# Altium schematic sheet SIB Int Press & Mux.SchDoc
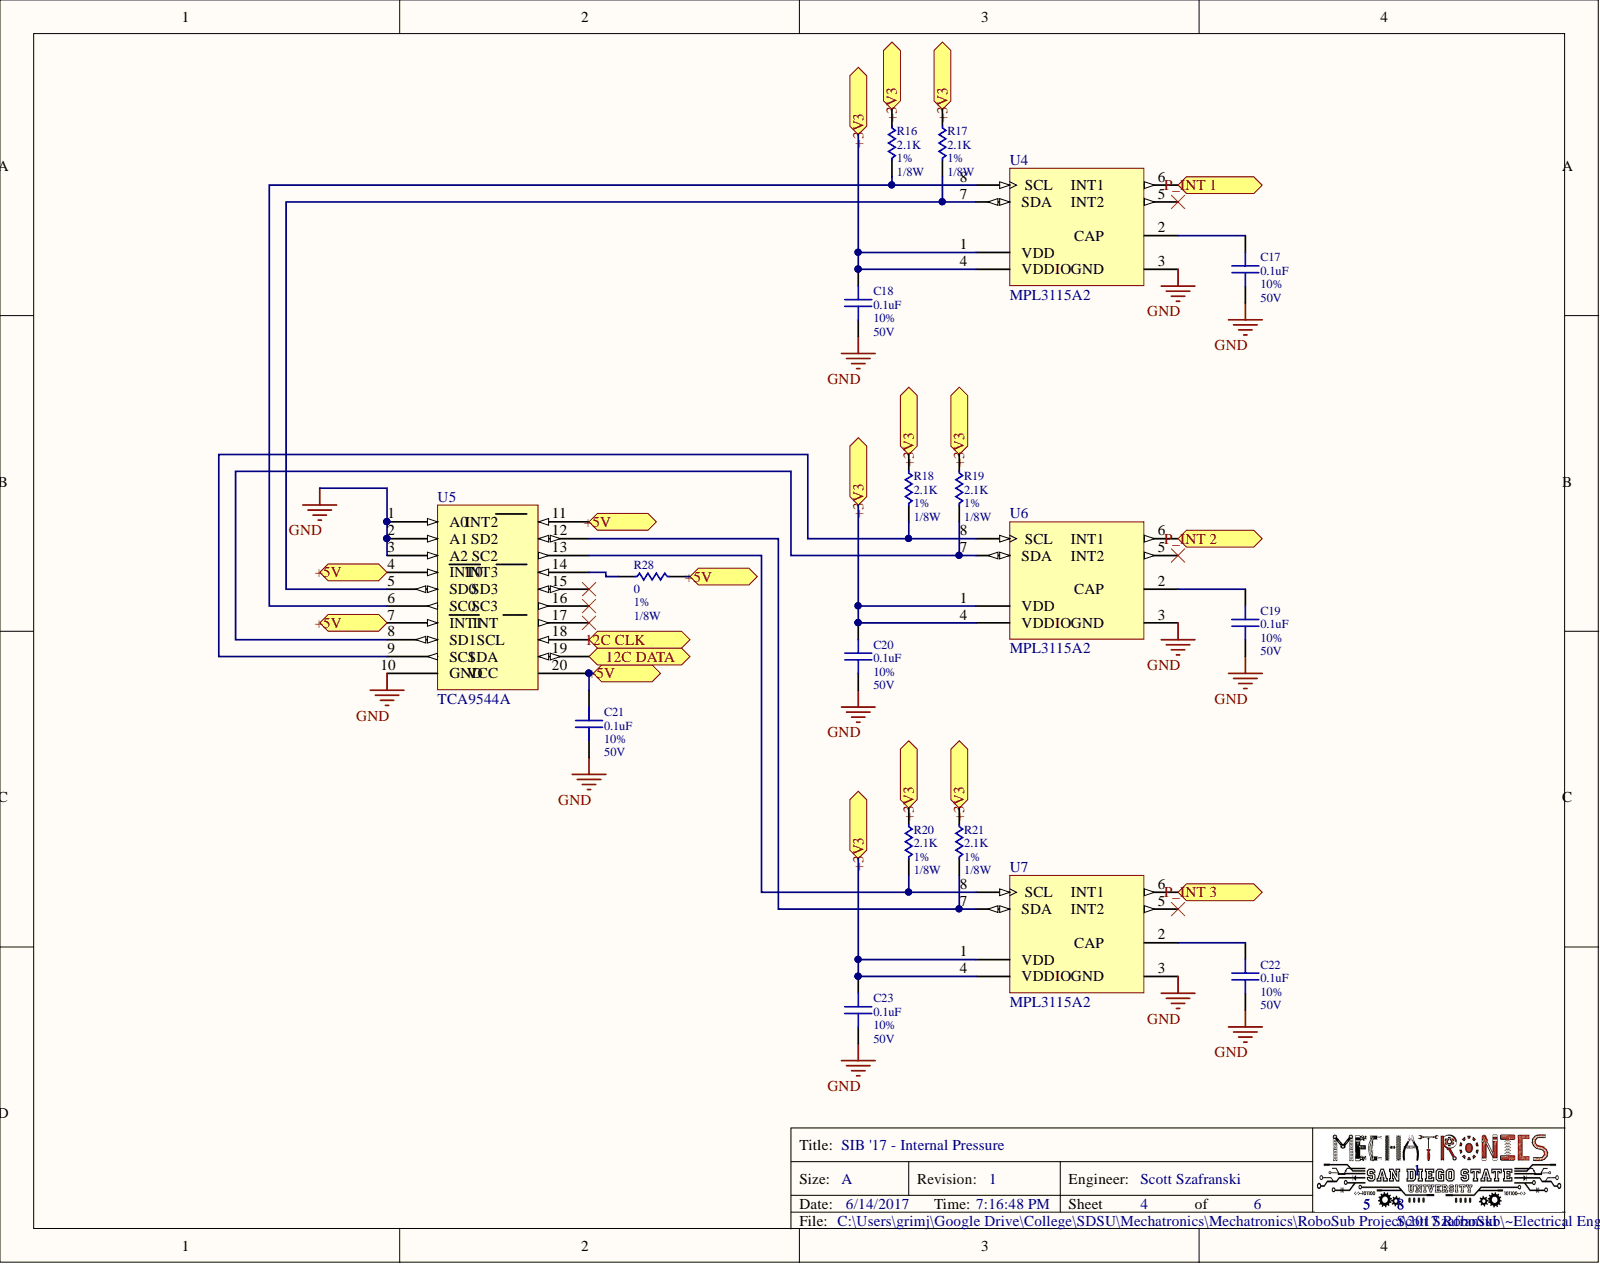
[diagram p0: SIB Int Press & Mux.SchDoc]

## Parts
C17  0.1uF  Tolerance: 10%  Voltage: 50V  [Component 0805]
C18  0.1uF  Tolerance: 10%  Voltage: 50V  [Component 0805]
C19  0.1uF  Tolerance: 10%  Voltage: 50V  [Component 0805]
C20  0.1uF  Tolerance: 10%  Voltage: 50V  [Component 0805]
C21  0.1uF  Tolerance: 10%  Voltage: 50V  [Component 0805]
C22  0.1uF  Tolerance: 10%  Voltage: 50V  [Component 0805]
C23  0.1uF  Tolerance: 10%  Voltage: 50V  [Component 0805]
R16  2.1K  Power: 1/8W  Tolerance: 1%  [Component 0805]
R17  2.1K  Power: 1/8W  Tolerance: 1%  [Component 0805]
R18  2.1K  Power: 1/8W  Tolerance: 1%  [Component 0805]
R19  2.1K  Power: 1/8W  Tolerance: 1%  [Component 0805]
R20  2.1K  Power: 1/8W  Tolerance: 1%  [Component 0805]
R21  2.1K  Power: 1/8W  Tolerance: 1%  [Component 0805]
R28  Tolerance: 1%  [Component 0805]  (hidden comment: Resistor)
U4  MPL3115A2  [FRES-2153-01_V]
U5  TCA9544A
U6  MPL3115A2  [FRES-2153-01_V]
U7  MPL3115A2  [FRES-2153-01_V]

## Ports
12C CLK, 12C DATA, +3V3, +5V, P_INT 1, P_INT 2, P_INT 3

## Nets
GND: C17.2 C18.2 C19.2 C20.2 C21.2 C22.2 C23.2 U4.3 U5.1(A0) U5.2(A1_SD2) U5.3(A2_SC2) U5.10(GND) U6.3 U7.3
12C CLK: U5.18 ; port 12C CLK
12C DATA: U5.19 ; port 12C DATA
+3V3: C18.1 C20.1 C23.1 R16.1 R17.1 R18.1 R19.1 R20.1 R21.1 U4.1(VDD) U4.4 U6.1(VDD) U6.4 U7.1(VDD) U7.4 ; port +3V3
+5V: C21.1 R28.2 U5.4(INT0) U5.7(INT1) U5.11 U5.20 ; port +5V
P_INT 1: U4.6 ; port P_INT 1
P_INT 2: U6.6 ; port P_INT 2
P_INT 3: U7.6 ; port P_INT 3
NetC17_1: C17.1 U4.2
NetC19_1: C19.1 U6.2
NetC22_1: C22.1 U7.2
NetR16_2: R16.2 U4.8(SCL) U5.6(SC0)
NetR17_2: R17.2 U4.7(SDA) U5.5(SD0)
NetR18_2: R18.2 U5.9(SC1) U6.8(SCL)
NetR19_2: R19.2 U5.8 U6.7(SDA)
NetR20_2: R20.2 U5.13 U7.8(SCL)
NetR21_2: R21.2 U5.12 U7.7(SDA)
NetR28_1: R28.1 U5.14

Not connected: U4 5; U5 15 16 17; U6 5; U7 5

## Library data of the parts
C17-C23 = Capacitor, Ceramic: Capacitance: 0.1uF; Component Kind: Standard; Library Name: MechatronicsSCH.SchLib
R16-R21 = Resistor: Thick Film Resistors - SMD 1/16watt 1%; Component Kind: Standard; Resistance: 2.1K; Library Name: MechatronicsSCH.SchLib
R28 = Resistor: Thick Film Resistors - SMD 1/16watt 1%; Power: 1/8W; Resistance: 0; Component Kind: Standard; Library Name: MechatronicsSCH.SchLib
U4 U6 U7 = CMP-1261-00002-2: Xtrinsic I2C Precision Altimeter, 1.95 to 3.6 V, -40 to 85 degC, 8-Pin LGA (2153-01), RoHS, Tray; Manufacturer: Freescale Semiconductor; fSCL@20 pF: 4 MHz Max.; fSCL@400 pF: 400 KHz Max.; VDDIO: 1.62 to 3.6 V; Component Kind: Standard; ComponentLink1Description: Manufacturer URL; ComponentLink1URL: http://www.freescale.com/; ComponentLink2Description: Datasheet; ComponentLink2URL: http://cache.freescale.com/files/sensors/doc/data_sheet/MPL3115A2.pdf; ComponentLink3Description: Package Specification; ComponentLink3URL: http://cache.freescale.com/files/shared/doc/package_info/98ASA00260D.pdf; DatasheetVersion: Rev. 3.0; Library Name: Altium Content Vault; Mounting Technology: Surface Mount; Operating Temperature Range: -40 to 85 degC; PackageDescription: 8-Pin Land Grid Array, Body 5 x 3 mm, Pitch 1.25 mm; PackageVersion: Rev. O; Packing: Tray; PartNumber: MPL3115A2; RoHS: TRUE; VDD: 1.95 to 3.6 V
U5 = TCA9544A: 4-Channel I2C Mux; Component Kind: Standard; Library Name: SIB_17_Sch_Lib.SchLib
